# Altium schematic sheet RS232.SchDoc(RS232)
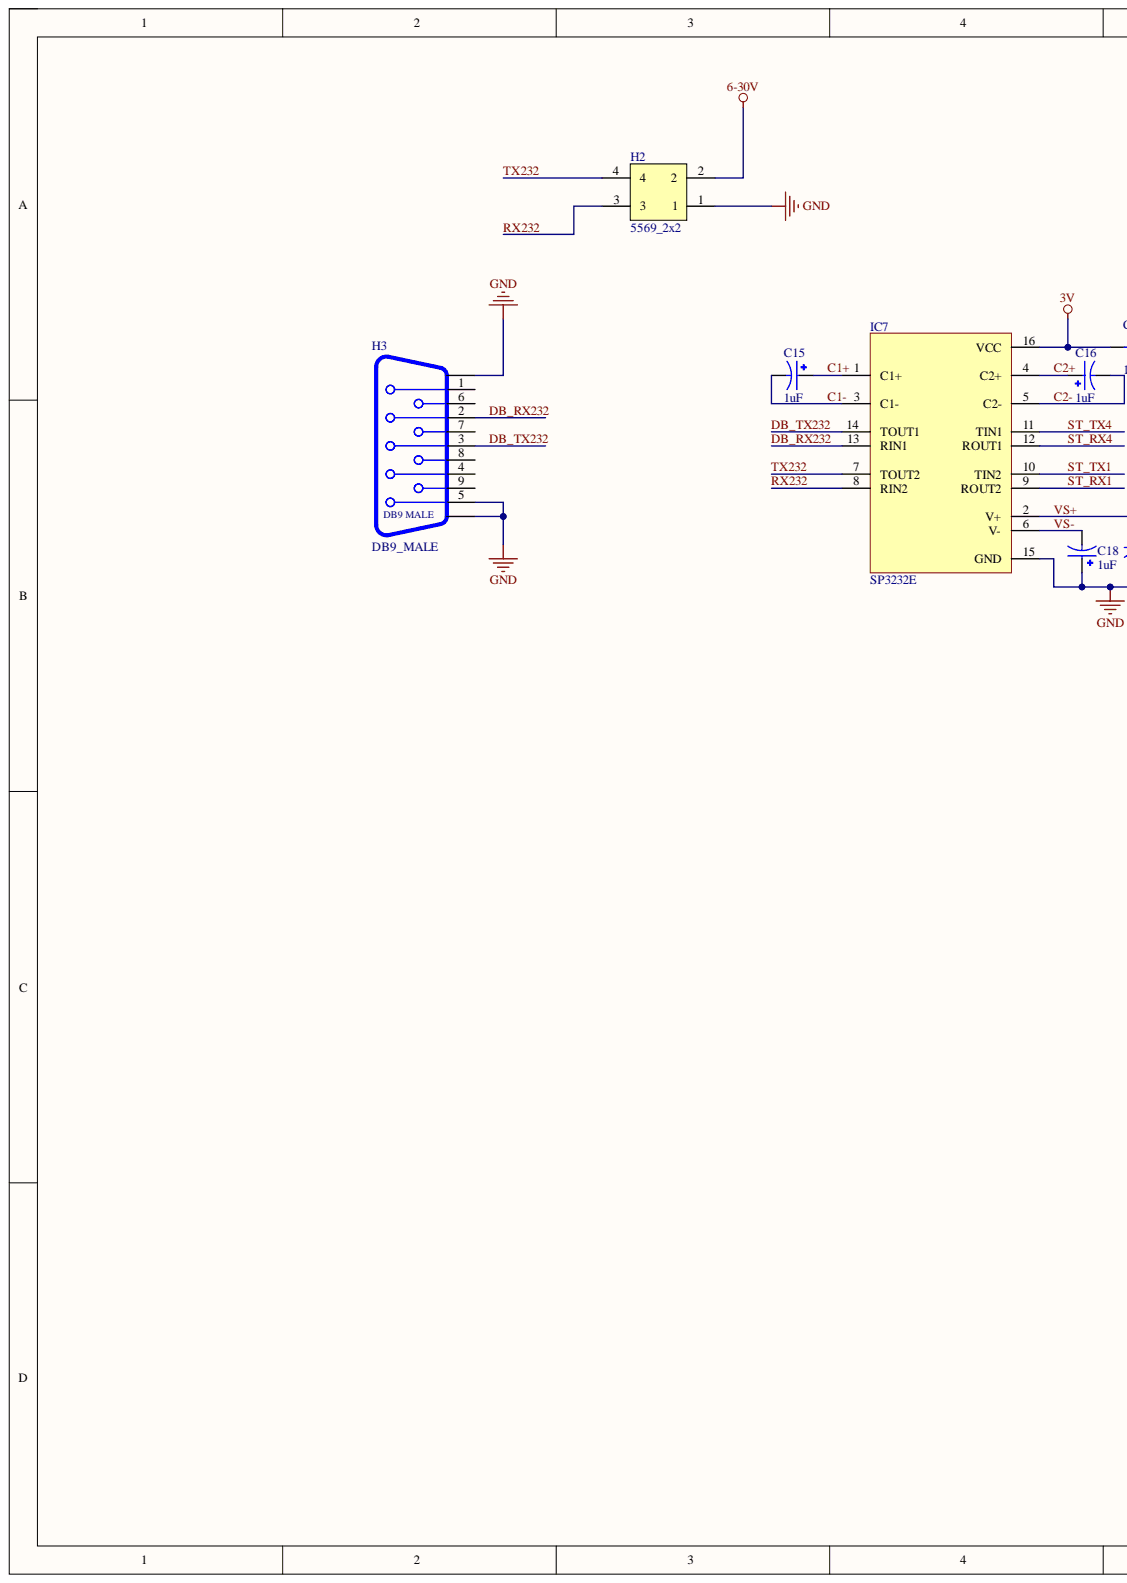
[diagram p0: RS232.SchDoc, left]
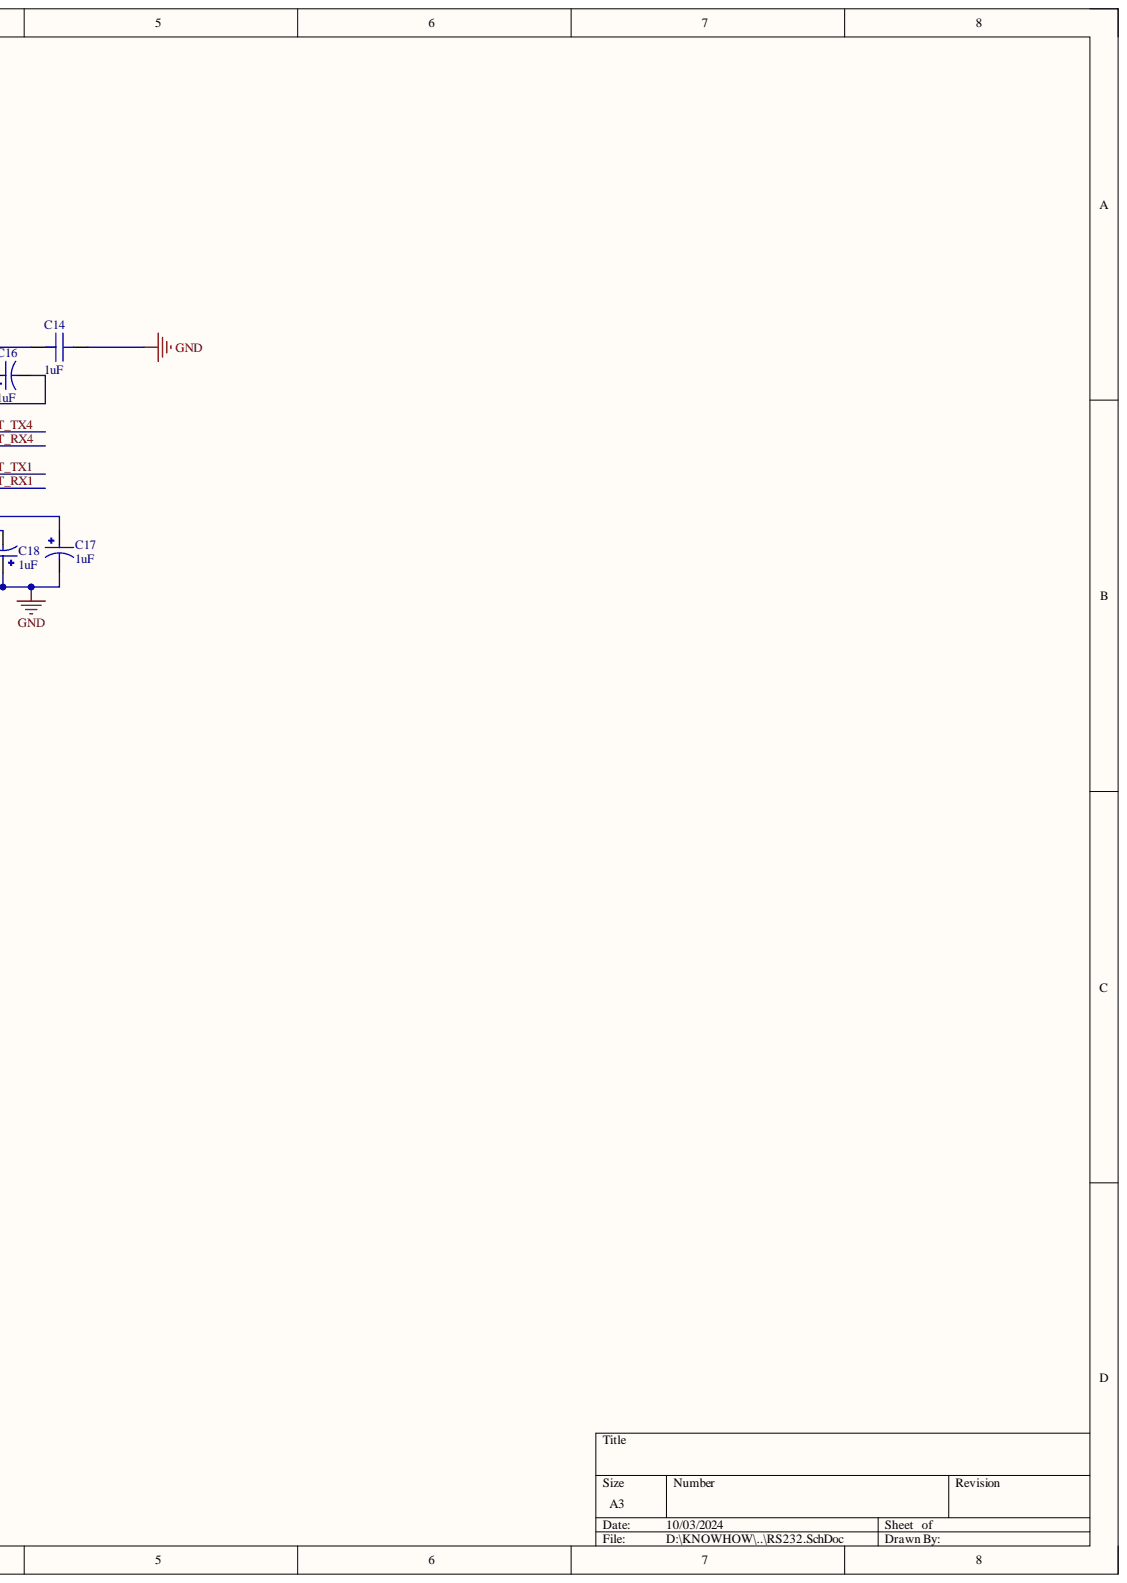
[diagram p1: RS232.SchDoc, right]

## Parts
C14  1uF  [C0603]
C15  1uF  [1206P]
C16  1uF  [1206P]
C17  1uF  [1206P]
C18  1uF  [1206P]
H2  5569_2x2  [5569_2x2]
H3  DB9_MALE  [DB9_MALE]
IC7  SP3232E  [SSOP-16]

## Nets
3V: C14.1 IC7.16(VCC)
6-30V: H2.2
GND: C14.2 C17.2 C18.1 H2.1 H3.5 H3.SHELL H3.SHELL1(DB9_MALE) IC7.15(GND)
C1+: C15.1 IC7.1(C1+)
C1-: C15.2 IC7.3(C1-)
C2+: C16.1 IC7.4(C2+)
C2-: C16.2 IC7.5(C2-)
DB_RX232: H3.2 IC7.13(RIN1)
DB_TX232: H3.3 IC7.14(TOUT1)
RX232: H2.3 IC7.8(RIN2)
ST_RX1: IC7.9(ROUT2)
ST_RX4: IC7.12(ROUT1)
ST_TX1: IC7.10(TIN2)
ST_TX4: IC7.11(TIN1)
TX232: H2.4 IC7.7(TOUT2)
VS+: C17.1 IC7.2(V+)
VS-: C18.2 IC7.6(V-)

Not connected: H3 1 4 6 7 8 9

## Library data of the parts
C14 = Capacitor 0603: Component Kind: Standard; Library Name: UY_Schlib.SchLib
C15-C18 = CAP_TAN_1206: Aluminum Electrolytic Capacitors - Radial Leaded 50VDC 1200uF 10000H 16x25mm; MF: Panasonic; MP: EEU-FS1H122; Availability: In Stock; Check_prices: https://www.snapeda.com/parts/EEU-FS1H122/Panasonic/view-part/?ref=eda; Component Kind: Standard; Package: RADIAL-2 Panasonic; Price: None; Purchase-URL: https://pricing.snapeda.com/search/part/EEU-FS1H122/?ref=eda; SnapEDA_Link: https://www.snapeda.com/parts/EEU-FS1H122/Panasonic/view-part/?ref=snap; Library Name: UY_Schlib.SchLib
H3 = DB9_MALE: 9 Position D-Sub Receptacle, Female Sockets Connector; MF: Wurth Electronics; MP: 618009233821; Availability: In Stock; Check_prices: https://www.snapeda.com/parts/618009233821/Wurth+Electronics+Inc./view-part/?ref=eda; Component Kind: Standard; Package: None; Price: None; Purchase-URL: https://pricing.snapeda.com/search/part/618009233821/?ref=eda; SnapEDA_Link: https://www.snapeda.com/parts/618009233821/Wurth+Electronics+Inc./view-part/?ref=snap; Library Name: UY_Schlib.SchLib
IC7 = SP3232E: 3-V to 5.5-V multichannel RS-232 line driver & receiver 16-SOIC -40 to 85; MF: Texas Instruments; MP: MAX3232IDR; Check_prices: https://www.snapeda.com/parts/MAX3232IDR/Texas%20Instruments/view-part/559735/?ref=eda; Component Kind: Standard; Digi-Key_Part_Number: 296-13098-1-ND; Package: SOIC-16 Texas Instruments; Purchase-URL: https://pricing.snapeda.com/search/part/MAX3232IDR/?ref=eda; SnapEDA_Link: https://www.snapeda.com/parts/MAX3232IDR/Texas%20Instruments/view-part/559735/?ref=snap; Library Name: UY_Schlib.SchLib
H2 = 5569_2x2: Component Kind: Standard; Library Name: UY_5569_Header.SchLib
